# Altium schematic sheet Cheeta_Controller.SchDoc
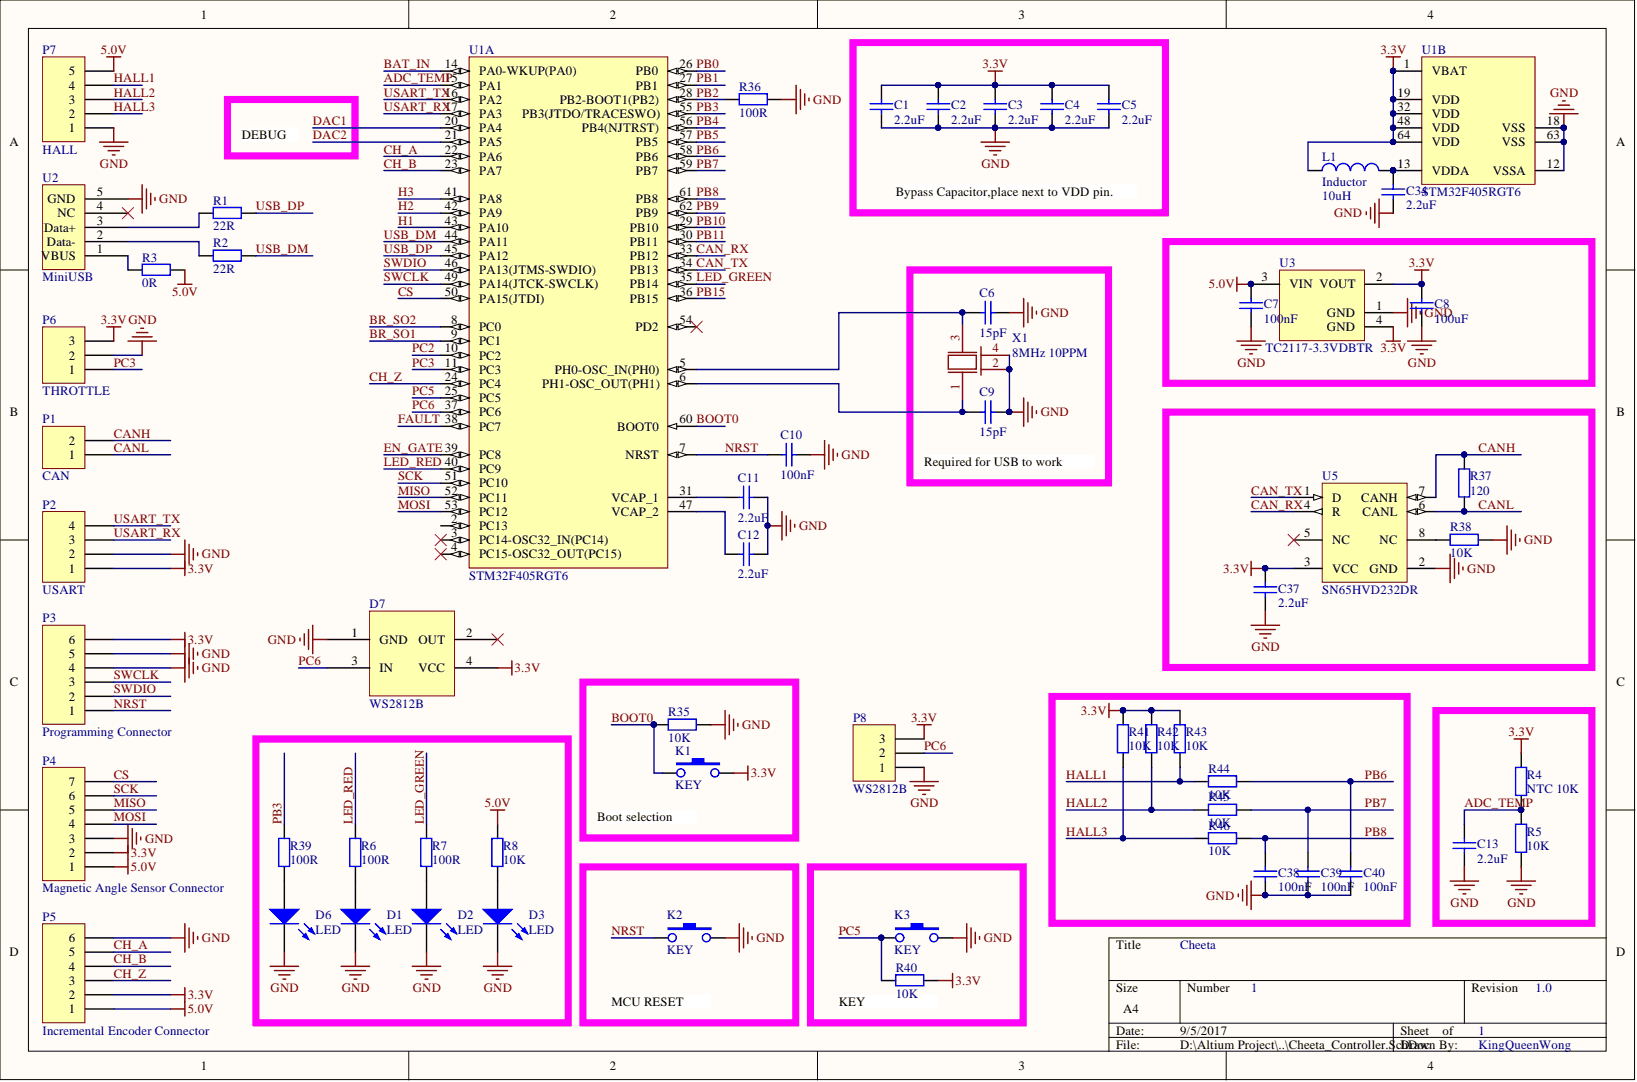
[diagram p0: Cheeta_Controller.SchDoc]

## Parts
C1  value 2.2uF  [0603C]  (hidden comment: Cap)
C2  Cap  value 2.2uF  [0603C]
C3  Cap  value 2.2uF  [0603C]
C4  value 2.2uF  [0603C]  (hidden comment: Cap)
C5  value 2.2uF  [0603C]  (hidden comment: Cap)
C6  value 15pF  [0603C]  (hidden comment: Cap)
C7  value 100nF  [0603C]  (hidden comment: Cap)
C8  value 100uF  [C1210]  (hidden comment: Cap)
C9  value 15pF  [0603C]  (hidden comment: Cap)
C10  value 100nF  [0603C]  (hidden comment: Cap)
C11  value 2.2uF  [0603C]  (hidden comment: Cap)
C12  value 2.2uF  [0603C]  (hidden comment: Cap)
C13  value 2.2uF  [0603C]  (hidden comment: Cap)
C34  value 2.2uF  [0603C]  (hidden comment: Cap)
C37  value 2.2uF  [0603C]  (hidden comment: Cap)
C38  value 100nF  [0603C]  (hidden comment: Cap)
C39  value 100nF  [0603C]  (hidden comment: Cap)
C40  value 100nF  [0603C]  (hidden comment: Cap)
D1  LED  [0603D]
D2  LED  [0603D]
D3  LED  [0603D]
D6  LED  [0603D]
D7  WS2812B  [WS2812B]
K1  KEY  [KEY3*4]
K2  KEY  [KEY3*4]
K3  KEY  [KEY3*4]
L1  Inductor  value 10uH  [0603C]
P1  CAN  [SH1.0_2P]
P2  USART  [SH1.0_4P]
P3  Programming Connector  [SH1.0_6P]
P4  Magnetic Angle Sensor Connector  [SH1.0_7P]
P5  Incremental Encoder Connector  [SH1.0_6P]
P6  THROTTLE  [SH1.0_3P]
P7  HALL  [SH1.0_5P]
P8  WS2812B  [SH1.0_3P]
R1  value 22R  [0603R]  (hidden comment: Res2)
R2  value 22R  [0603R]  (hidden comment: Res2)
R3  value 0R  [0603R]  (hidden comment: Res2)
R4  value NTC 10K  [0603R]  (hidden comment: Res2)
R5  value 10K  [0603R]  (hidden comment: Res2)
R6  value 100R  [0603R]  (hidden comment: Res2)
R7  value 100R  [0603R]  (hidden comment: Res2)
R8  value 10K  [0603R]  (hidden comment: Res2)
R35  value 10K  [0603R]  (hidden comment: Res2)
R36  value 100R  [0603R]  (hidden comment: Res2)
R37  value 120  [0603R]  (hidden comment: Res2)
R38  value 10K  [0603R]  (hidden comment: Res2)
R39  value 100R  [0603R]  (hidden comment: Res2)
R40  value 10K  [0603R]  (hidden comment: Res2)
R41  value 10K  [0603R]  (hidden comment: Res2)
R42  value 10K  [0603R]  (hidden comment: Res2)
R43  value 10K  [0603R]  (hidden comment: Res2)
R44  value 10K  [0603R]  (hidden comment: Res2)
R45  value 10K  [0603R]  (hidden comment: Res2)
R46  value 10K  [0603R]  (hidden comment: Res2)
U1  STM32F405RGT6  [LQFP64]  (parts A,B)
U2  MiniUSB  [MINI-USB]
U3  TC2117-3.3VDBTR  [SOT-223-DB3_N]
U5  SN65HVD232DR  [SN65HVD232]
X1  8MHz 10PPM  [ABM3B]

## Nets
3.3V: C1.2 C2.2 C3.2 C4.2 C5.2 C8.2 C37.1 D7.4(VCC) K1.2 L1.1 P2.1 P3.6 P4.2 P5.2 P6.3 P8.3 R4.1 R40.1 R41.2 R42.2 R43.2 U1.1(VBAT) U1.19(VDD) U1.32(VDD) U1.48(VDD) U1.64(VDD) U3.2(VOUT) U3.4(GND) U5.3(VCC)
5.0V: C7.2 P4.1 P5.1 P7.5 R3.1 R8.2 U3.3(VIN)
GND: C1.1 C2.1 C3.1 C4.1 C5.1 C6.2 C7.1 C8.1 C9.2 C10.2 C11.2 C12.2 C13.1 C34.1 C37.2 C38.1 C39.1 C40.1 D1.2 D2.2 D3.2 D6.2 D7.1(GND) K2.2 K3.2 P2.2 P3.4 P3.5 P4.3 P5.6 P6.2 P7.1 P8.1 R5.2 R35.1 R36.1 R38.2 U1.12(VSSA) U1.18(VSS) U1.63(VSS) U2.5(GND) U3.1(GND) U5.2(GND) X1.2 X1.4
ADC_TEMP: C13.2 R4.2 R5.1 U1.15(PA1)
BAT_IN: U1.14(PA0-WKUP(PA0))
BOOT0: K1.1 R35.2 U1.60(BOOT0)
BR_SO1: U1.9(PC1)
BR_SO2: U1.8(PC0)
CAN_RX: U1.33(PB12) U5.4(R)
CAN_TX: U1.34(PB13) U5.1(D)
CANH: P1.2 R37.2 U5.7(CANH)
CANL: P1.1 R37.1 U5.6(CANL)
CH_A: P5.5 U1.22(PA6)
CH_B: P5.4 U1.23(PA7)
CH_Z: P5.3 U1.24(PC4)
CS: P4.7 U1.50(PA15(JTDI))
DAC1: U1.20(PA4)
DAC2: U1.21(PA5)
EN_GATE: U1.39(PC8)
FAULT: U1.38(PC7)
H1: U1.43(PA10)
H2: U1.42(PA9)
H3: U1.41(PA8)
HALL1: P7.4 R43.1 R44.2
HALL2: P7.3 R42.1 R45.2
HALL3: P7.2 R41.1 R46.2
LED_GREEN: R7.2 U1.35(PB14)
LED_RED: R6.2 U1.40(PC9)
MISO: P4.5 U1.52(PC11)
MOSI: P4.4 U1.53(PC12)
NRST: C10.1 K2.1 P3.1 U1.7(NRST)
PB0: U1.26(PB0)
PB1: U1.27(PB1)
PB2: R36.2 U1.28(PB2-BOOT1(PB2))
PB3: R39.2 U1.55(PB3(JTDO/TRACESWO))
PB4: U1.56(PB4(NJTRST))
PB5: U1.57(PB5)
PB6: C40.2 R44.1 U1.58(PB6)
PB7: C39.2 R45.1 U1.59(PB7)
PB8: C38.2 R46.1 U1.61(PB8)
PB9: U1.62(PB9)
PB10: U1.29(PB10)
PB11: U1.30(PB11)
PB15: U1.36(PB15)
PC2: U1.10(PC2)
PC3: P6.1 U1.11(PC3)
PC5: K3.1 R40.2 U1.25(PC5)
PC6: D7.3(IN) P8.2 U1.37(PC6)
SCK: P4.6 U1.51(PC10)
SWCLK: P3.3 U1.49(PA14(JTCK-SWCLK))
SWDIO: P3.2 U1.46(PA13(JTMS-SWDIO))
USART_RX: P2.3 U1.17(PA3)
USART_TX: P2.4 U1.16(PA2)
USB_DM: R2.1 U1.44(PA11)
USB_DP: R1.1 U1.45(PA12)
NetC6_1: C6.1 U1.5(PH0-OSC_IN(PH0)) X1.3
NetC9_1: C9.1 U1.6(PH1-OSC_OUT(PH1)) X1.1
NetC11_1: C11.1 U1.31(VCAP_1)
NetC12_1: C12.1 U1.47(VCAP_2)
NetC34_2: C34.2 L1.2 U1.13(VDDA)
NetD1_1: D1.1 R6.1
NetD2_1: D2.1 R7.1
NetD3_1: D3.1 R8.1
NetD6_1: D6.1 R39.1
NetR1_2: R1.2 U2.3(Data+)
NetR2_2: R2.2 U2.2(Data-)
NetR3_2: R3.2 U2.1(VBUS)
NetR38_1: R38.1 U5.8(NC)

Not connected: D7 2(OUT); U1 2(PC13) 3(PC14-OSC32_IN(PC14)) 4(PC15-OSC32_OUT(PC15)) 54(PD2); U2 4(NC); U5 5(NC)

## Library data of the parts
C1-C13 C34 C37-C40 = Cap: Capacitor; Component Kind: Standard; LatestRevisionDate: 17-Jul-2002; LatestRevisionNote: Re-released for DXP Platform.; Library Name: Miscellaneous Devices.IntLib; PackageDescription: Radial Cap, Thru-Hole; 2 Leads; 0.3 in Pin Spacing; PackageReference: RAD-0.3; Published: 8-Jun-2000; Signal Integrity: Cap; Simulation: CAP; Publisher: Altium Limited
D1-D3 D6 = LED0: Typical INFRARED GaAs LED; Component Kind: Standard; LatestRevisionDate: 08-Jul-2005; LatestRevisionNote: Mech Layer 1 removed.; Library Name: Miscellaneous Devices.IntLib; PackageDescription: LED; 2 Leads; PackageReference: LED-0; Published: 8-Jun-2000; Simulation: LED0; Publisher: Altium Limited
L1 = Inductor: Code_IEC: 1005; Code_JEITA: 0402; Component Kind: Standard; Description: Inductor; LatestRevisionDate: 29-May-2009; LatestRevisionNote: IPC-7351 Footprint Added.; Library Name: Miscellaneous Devices.IntLib; PackageDescription: Chip Inductor; PackageReference: 0402-A; Published: 8-Jun-2000; Signal Integrity: Inductor; Simulation: INDUCTOR; Publisher: Altium Limited
P1 = Header 2: Header, 2-Pin; Component Kind: Standard; LatestRevisionDate: 17-Jul-2002; LatestRevisionNote: Re-released for DXP Platform.; Library Name: Miscellaneous Connectors.IntLib; Signal Integrity: Connector; Publisher: Altium Limited
P2 = MHDR1X4: Header, 4-Pin; Component Kind: Standard; LatestRevisionDate: 17-Jul-2002; LatestRevisionNote: Re-released for DXP Platform.; Library Name: Miscellaneous Connectors.IntLib; Signal Integrity: Connector; Publisher: Altium Limited
P3 P5 = MHDR1X6: Header, 6-Pin; Component Kind: Standard; LatestRevisionDate: 17-Jul-2002; LatestRevisionNote: Re-released for DXP Platform.; Library Name: Miscellaneous Connectors.IntLib; Signal Integrity: Connector; Publisher: Altium Limited
P4 = MHDR1X7: Header, 7-Pin; Component Kind: Standard; LatestRevisionDate: 17-Jul-2002; LatestRevisionNote: Re-released for DXP Platform.; Library Name: Miscellaneous Connectors.IntLib; Signal Integrity: Connector; Publisher: Altium Limited
P6 P8 = Header 3: Header, 3-Pin; Component Kind: Standard; LatestRevisionDate: 17-Jul-2002; LatestRevisionNote: Re-released for DXP Platform.; Library Name: Miscellaneous Connectors.IntLib; Signal Integrity: Connector; Publisher: Altium Limited
P7 = Header 5: Header, 5-Pin; Component Kind: Standard; LatestRevisionDate: 17-Jul-2002; LatestRevisionNote: Re-released for DXP Platform.; Library Name: Miscellaneous Connectors.IntLib; Signal Integrity: Connector; Publisher: Altium Limited
R1-R8 R35-R46 = Res2: Resistor; Component Kind: Standard; LatestRevisionDate: 17-Jul-2002; LatestRevisionNote: Re-released for DXP Platform.; Library Name: Miscellaneous Devices.IntLib; PackageDescription: Axial Device, Thru-Hole; 2 Leads; 0.4 in Pin Spacing; PackageReference: AXIAL-0.4; Published: 8-Jun-2000; Signal Integrity: Res; Simulation: RESISTOR; Publisher: Altium Limited
U1 = STM32F405RGT6: ARM Cortex-M4 32-bit MCU+FPU, 210 DMIPS, 1024 kB Flash, 192 kB Internal RAM, 51 I/Os, 64-pin LQFP, -40 to 85 degC, Tray; Manufacturer: STMicroelectronics; D/A Converter: 2x12-bit; FLASH Size (Prog) (kB): 1024; I/Os (High Current): 51; Internal RAM Size (kB): 192; Operating Frequency(F) (Processor speed) (MHz): 168; Supply Voltage(Vcc) max (V): 3.6; Supply Voltage(Vcc) min (V): 1.8; Component Kind: Standard; ComponentLink1Description: Manufacturer URL; ComponentLink1URL: http://www.st.com/web/en/home.html; ComponentLink2Description: Datasheet; ComponentLink2URL: http://www.st.com/st-web-ui/static/active/en/resource/technical/document/datasheet/DM00037051.pdf; ComponentLink3Description: Package Specification; ComponentLink3URL: http://www.st.com/st-web-ui/static/active/en/resource/technical/document/datasheet/DM00037051.pdf; Core: ARM Cortex-M4; DatasheetVersion: Rev. 4; Library Name: STMicroelectronics STM32 F4.IntLib; Mounting Technology: Surface Mount; PackageDescription: 64-Pin Low Profile Quad Flat Package, 10 x 10 mm Body, 0.5 mm Pitch; PackageReference: LQFP64; PackageVersion: Rev. 4, 06/2013; Packing: Tray; PartNumber: STM32F405RGT6; Supplier 1: Digi-Key; Supplier 2: Farnell; Supplier 3: Newark; Supplier 4: Mouser; Supplier Part Number 1: 497-11767-ND; Supplier Part Number 2: 2064363; Supplier Part Number 3: 73T2329; Supplier Part Number 4: 511-STM32F405RGT6
U3 = TC2117-3.3VDBTR: 800 mA Fixed Low Dropout Positive Regulator, 3-Pin SOT-223, Extended Temperature, Tape and Reel; Manufacturer: Microchip; Component Kind: Standard; ComponentLink1Description: Manufacturer URL; ComponentLink1URL: http://www.microchip.com/; ComponentLink2Description: Datasheet; ComponentLink2URL: http://ww1.microchip.com/downloads/en/DeviceDoc/21665c.pdf; ComponentLink3Description: Package Specification; ComponentLink3URL: http://www.microchip.com/stellent/groups/techpub_sg/documents/packagingspec/en012702.pdf; DatasheetVersion: revC, Oct-2006; Library Name: Microchip Power Management Line Regulator.IntLib; Mounting Technology: Surface Mount; PackageDescription: 3-Lead Plastic Small Outline Transistor (DB) [SOT-223]; PackageReference: SOT-223-DB3; PackageVersion: revBB, Aug-2009; PartNumber: TC2117-3.3VDBTR; Supplier 1: Digi-Key; Supplier 2: Farnell; Supplier 3: Farnell; Supplier 4: Newark; Supplier 5: Mouser; Supplier Part Number 1: TC2117-3.3VDBTR-ND; Supplier Part Number 2: 1605581RL; Supplier Part Number 3: 1605581; Supplier Part Number 4: 11N8302; Supplier Part Number 5: 579-TC2117-3.3VDBTR
U5 = SN65HVD232DR: 3.3 V Can Transceiver, 17 mA, -40 to 85 degC, 8-pin SOIC (D), Green (RoHS & no Sb/Br); Manufacturer: Texas Instruments; Drivers Per Package: 1; ICC(Max)(mA): 17; Rating: Catalog; Supply Voltage(s)(V): 3.3; Transient Pulse Protection(V): -25 to 25; Code_JEDEC: MS-012AA; Component Kind: Standard; ComponentLink1Description: Datasheet; ComponentLink1URL: http://www.ti.com/general/docs/lit/getliterature.tsp?genericPartNumber=SN65HVD232&fileType=pdf; DatasheetVersion: SLOS346K; Eco-Plan: Green (RoHS & no Sb/Br); Isolated: No; Library Name: Texas Instruments Interface CAN.IntLib; Mounting Technology: Surface Mount; PackageDescription: 8-Pin Plastic Small Outline Integrated Circuit, 4.9 x 3.9 mm, Pitch 1.27 mm Pitch; PackageReference: D8; PackageVersion: revM, Jun-2011; PartNumber: SN65HVD232DR; Receivers Per Package: 1; SubFamily: CAN; Supplier 1: Digi-Key; Supplier 2: Digi-Key; Supplier 3: Digi-Key; Supplier 4: Newark; Supplier 5: Mouser; Supplier Part Number 1: 296-26344-2-ND; Supplier Part Number 2: 296-26344-1-ND; Supplier Part Number 3: 296-26344-6-ND; Supplier Part Number 4: 75C7753; Supplier Part Number 5: 595-SN65HVD232DR
X1 = TZ1430A: Crystal Oscillator; Component Kind: Standard; Library Name: CKM.SchLib
D7 = WS2812B: Component Kind: Standard; Description: WS2812B; Library Name: FreshPersimmon_Schematic.SchLib
K1-K3 = KEY: Component Kind: Standard; Description: KEY; Library Name: Schlib.SchLib
U2 = MiniUSB: Component Kind: Standard; Description: MiniUSB; Library Name: FreshPersimmon_Schematic.SchLib
